# Altium schematic sheet TPC.SchDoc
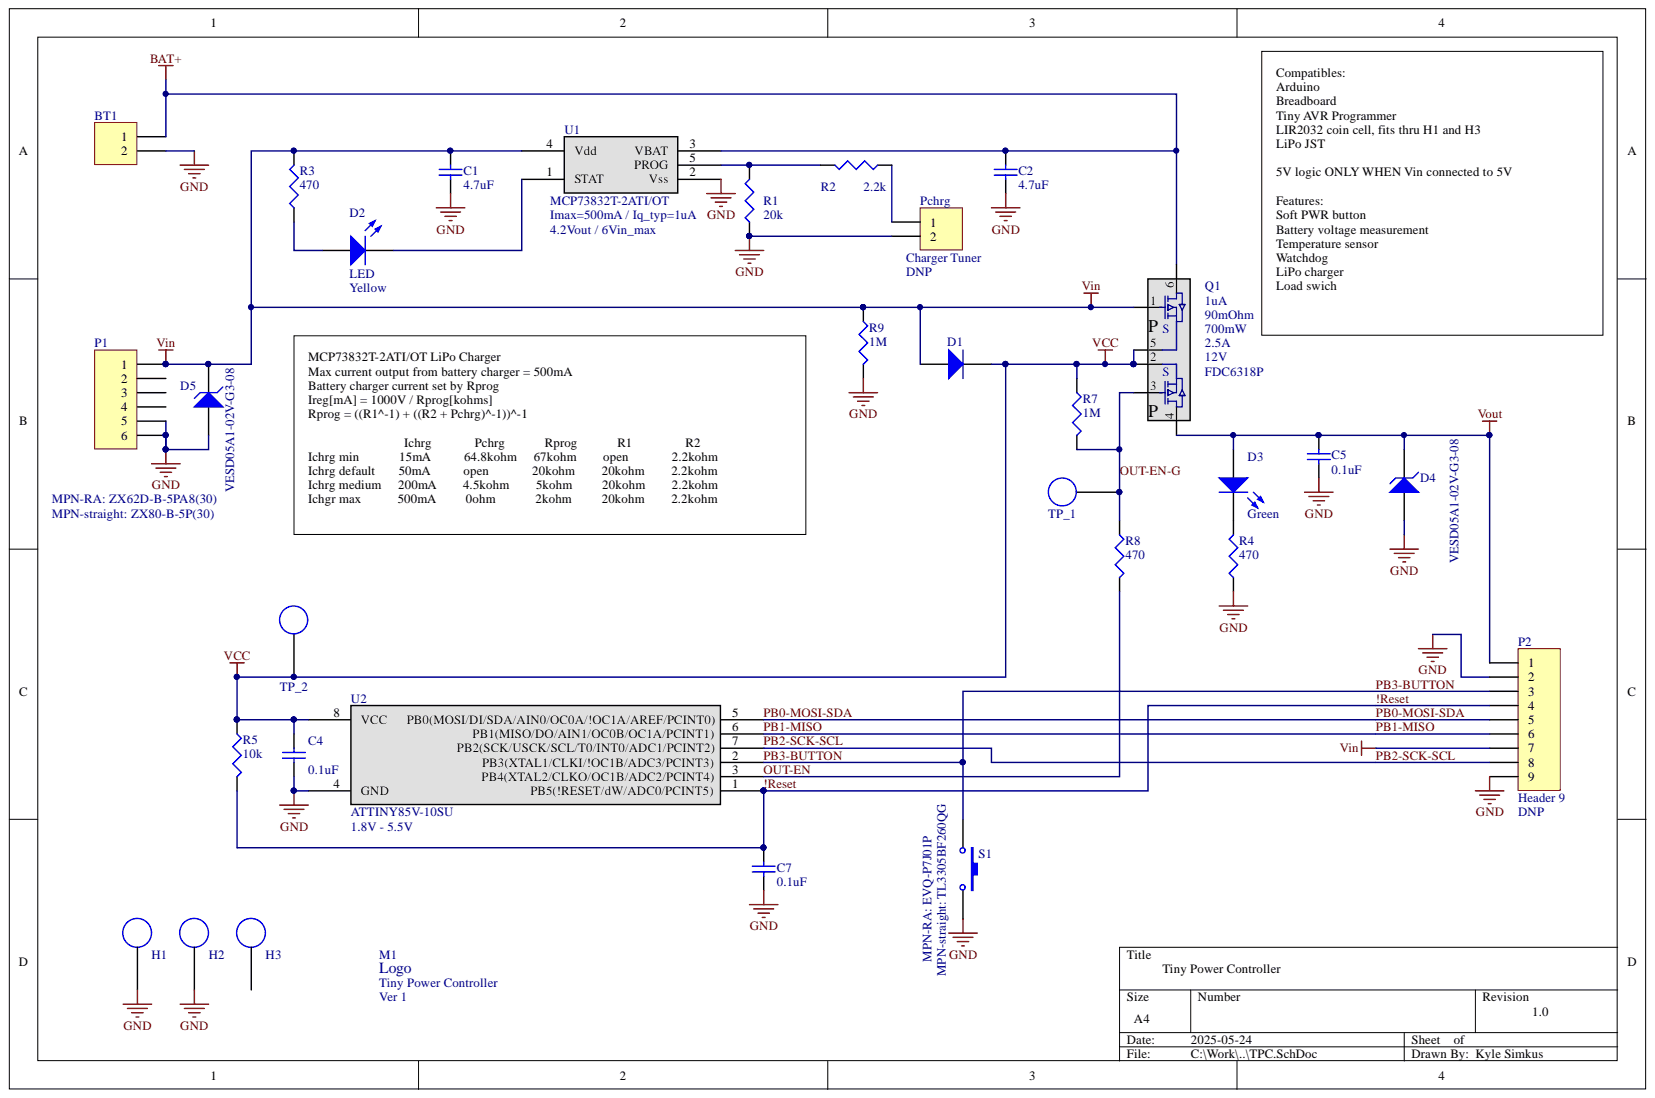
[diagram p0: TPC.SchDoc]

## Parts
BT1  JST connector  [JST-DUAL-Batt - 2mm 3_5mm]  (value not drawn)
C1  value 4.7uF  [C0603]  (hidden comment: CAP)
C2  value 4.7uF  [C0603]  (hidden comment: CAP)
C4  value 0.1uF  [C0603]  (hidden comment: CAP)
C5  value 0.1uF  [C0603]  (hidden comment: CAP)
C7  value 0.1uF  [C0603]  (hidden comment: CAP)
D1  Diode  [SOD128]  (value not drawn)
D2  LED  value Yellow  [0805 [2012] LED]
D3  value Green  [0805 [2012] LED]  (hidden comment: LED)
D4  DIODE  [SOD523]  (value not drawn)
D5  MPN: VESD05A1-02V-G3-08  [SOD523]  (hidden comment: DIODE)
H1  MountingHole  [Mounting-Hole-2-56]  (value not drawn)  (no BOM)
H2  MountingHole  [Mounting-Hole-2-56]  (value not drawn)  (no BOM)
H3  MountingHole  [Mounting-Hole-2-56 - isolated]  (value not drawn)  (no BOM)
M1  Ver 1  [Logo-KAS]  (no BOM)
P1  USB micro  [USBmicro-DUAL Hirose Dual sided]  (value not drawn)
P2  Header 9  [HDR1X9]  (no BOM)
Pchrg  Charger Tuner  [HDR1X2]  (no BOM)
Q1  Id: 2.5A  Idss: 1uA  MPN: FDC6318P  Pmax: 700mW  Rds: 90mOhm  Vdss: 12V  [SOT-23-6]  (hidden comment: 2x PMOS)
R1  value 20k  [R0603]  (hidden comment: RES)
R2  value 2.2k  [R0603]  (hidden comment: RES)
R3  value 470  [R0603]  (hidden comment: RES)
R4  value 470  [R0603]  (hidden comment: RES)
R5  value 10k  [R0603]  (hidden comment: RES)
R7  value 1M  [R0603]  (hidden comment: RES)
R8  value 470  [R0603]  (hidden comment: RES)
R9  value 1M  [R0603]  (hidden comment: RES)
S1  MPN: EVQ-P7J01P  MPN-straight: TL3305BF260QG  [SW Multi TH]  (hidden comment: BUTTON)
TP_1  EN-Out  [TestPoint-1_8-smt]  (value not drawn)  (no BOM)
TP_2  Vcc  [TestPoint-1_8-smt]  (value not drawn)  (no BOM)
U1  Current: Imax=500mA / Iq_typ=1uA  [SOT-23-5]  (hidden comment: Charger)
U2  MPN: ATTINY85V-10SU  Voltage: 1.8V - 5.5V  [8-SOIC-5.3mm]  (hidden comment: MCU)

## Nets
BAT+: BT1.1 C2.2 Q1.6 U1.3(VBAT)
GND: BT1.2 C1.1 C2.1 C4.1 C5.1 C7.1 D4.1 D5.1 H1.0 H2.0 P1.5 P1.6 P2.2 P2.9 Pchrg.2 R1.1 R4.1 R9.2 S1.1 U1.2(Vss) U2.4(GND)
VCC: C4.2 D1.2 Q1.2 Q1.5 R5.1 R7.1 TP_2.0 U2.8(VCC)
VIN: C1.2 D1.1 D5.2 P1.1 P2.7 Q1.1 R3.2 R9.1 U1.4(Vdd)
VOUT: C5.2 D3.1 D4.2 P2.1 Q1.4
!RESET: C7.2 P2.4 R5.2 U2.1(PB5(!RESET/dW/ADC0/PCINT5))
OUT-EN: R8.2 U2.3(PB4(XTAL2/CLKO/OC1B/ADC2/PCINT4))
OUT-EN-G: Q1.3 R7.2 R8.1 TP_1.0
PB0-MOSI-SDA: P2.5 U2.5(PB0(MOSI/DI/SDA/AIN0/OC0A/!OC1A/AREF/PCINT0))
PB1-MISO: P2.6 U2.6(PB1(MISO/DO/AIN1/OC0B/OC1A/PCINT1))
PB2-SCK-SCL: P2.8 U2.7(PB2(SCK/USCK/SCL/T0/INT0/ADC1/PCINT2))
PB3-BUTTON: P2.3 S1.2 U2.2(PB3(XTAL1/CLKI/!OC1B/ADC3/PCINT3))
NetD2_1: D2.1 R3.1
NetD2_2: D2.2 U1.1(STAT)
NetD3_2: D3.2 R4.2
NetPchrg_1: Pchrg.1 R2.1
NetR1_2: R1.2 R2.2 U1.5(PROG)

Not connected: H3 0; P1 2 3 4

## Library data of the parts
BT1 = Header 2: CONN HEADER VERT 2POS 2MM; MPN: B2B-PH-K-S(LF)(SN); MPN-seeedSKU: 320170005; Component Kind: Standard; JLC-PN: C131337; Library Name: Miscellaneous Connectors.IntLib; MPN2: S2B-PH-K-S(LF)(SN)
C1 C2 = Cap Semi: CAP CER 4.7UF 10V X5R 0603; MPN: CL10A475KP8NNNC; Voltage: V; Component Kind: Standard; JLC-PN: C1705; Library Name: Miscellaneous Devices.IntLib; Simulation: CAP
C4 C5 C7 = Cap Semi: CAP CER 0.1UF 16V X7R 0603; MPN: CL10B104KO8NNNC; Voltage: V; Component Kind: Standard; JLC-PN: C1525; Library Name: Miscellaneous Devices.IntLib; Simulation: CAP
D1 = Diode: DIODE SCHOTTK 60V 3A SOD128/CFP5; MPN: PMEG6030ELPX; Value: 60V 3A; Component Kind: Standard; JLC-PN: C2908821; Library Name: Miscellaneous Devices.IntLib; Simulation: DIODE
D2 = LED2: LED YELLOW CLEAR 0805 SMD; MPN: QTLP630C3TR; DKPN: 1080-1410-1-ND; Component Kind: Standard; JLC-PN: C6958991; Library Name: Miscellaneous Devices.IntLib; Simulation: LED2
D3 = LED2: LED GREEN CLEAR 0805 SMD; MPN: QTLP630C4TR/FC17-21SYGC/S530-E2/TR8; Component Kind: Standard; JLC-PN: C6368479; Library Name: Miscellaneous Devices.IntLib; Simulation: LED2
D4 = D Zener: TVS DIODE 5VWM 12VC SOD523; MPN: VESD05A1-02V-G3-08; MPNbig: SD05T1G; MPNoldTiny: SP1003-01DTG; Component Kind: Standard; JLC-PN: C3703729; Library Name: Miscellaneous Devices.IntLib; Simulation: ZENER
D5 = D Zener: TVS DIODE 5VWM 12VC SOD523; MPNbig: SD05T1G; MPNoldTiny: SP1003-01DTG; Component Kind: Standard; JLC-PN: C3703729; Library Name: Miscellaneous Devices.IntLib; Simulation: ZENER
M1 = Logo-KAS: Logo; FullName: Tiny Power Controller; DNP: DNP; Library Name: EG.SchLib
P1 = Header 6: CONN RCPT USB2.0 MICRO B SMD R/A; MPN: ZX62D-B-5PA8(30); MPN-straight: ZX80-B-5P(30); Component Kind: Standard; JLC-PN: C132350; Library Name: Miscellaneous Connectors.IntLib; MPN-RA: ZX62D-B-5PA8(30)
P2 = Header 9: Header, 9-Pin; DNP: DNP; Library Name: Miscellaneous Connectors.IntLib
Pchrg = Header 2: Header, 2-Pin; DNP: DNP; Library Name: Miscellaneous Connectors.IntLib
Q1 = MOSFET-P-x2: MOSFET 2P-CH 12V 2.5A SSOT-6; Component Kind: Standard; JLC-PN: C157543; MPN2: DMP2240UDM-7; Library Name: TPC.SchLib
R1 = Res3: RES SMD 20K OHM 1% 1/10W 0603; MPN: ERJ-3EKF2002V; Component Kind: Standard; JLC-PN: C403057; Library Name: Miscellaneous Devices.IntLib; Simulation: RESISTOR
R2 = Res3: RES SMD 2.2K OHM 1% 1/10W 0603; MPN: ERJ3EKF2201V; Component Kind: Standard; JLC-PN: C116387; Library Name: Miscellaneous Devices.IntLib; Simulation: RESISTOR
R3 R4 R8 = Res3: RES SMD 470 OHM 5% 1/10W 0603; MPN: ERJ-3GEYJ471V; Component Kind: Standard; JLC-PN: C169852; Library Name: Miscellaneous Devices.IntLib; Simulation: RESISTOR
R5 = Res3: Resistor; MPN: ERJ-3GEYJ103V; Component Kind: Standard; JLC-PN: C169849; Library Name: Miscellaneous Devices.IntLib; Simulation: RESISTOR
R7 R9 = Res3: RES SMD 1M OHM 5% 1/10W 0603; MPN: ERJ-3GEYJ105V; Component Kind: Standard; JLC-PN: C278634; Library Name: Miscellaneous Devices.IntLib; Simulation: RESISTOR
S1 = SW-PB: SWITCH TACTILE SPST-NO 0.05A 12V; Component Kind: Standard; JLC-PN: C2828254; Library Name: Miscellaneous Devices.IntLib; MPN-RA: EVQ-P7J01P
TP_1 TP_2 = TestPoint: DNP: DNP; Library Name: TPC.SchLib
U1 = MCP73832T-2ATI/OT: LiPo Charger 500mA 4.2V; Voltage: 4.2Vout / 6Vin_max; Component Kind: Standard; JLC-PN: C173380; Library Name: EG.SchLib; MPN: MCP73832T-2ATI/OT
U2 = ATtiny85-20SU: IC MCU 8BIT 8KB FLASH 8SOIC; Component Kind: Standard; JLC-PN: C152192; Library Name: ATP.SchLib
H1-H3 = MountingHole: DNP: DNP; Library Name: TPC.SchLib
